# Altium schematic sheet uczelnia_robot.SchDoc
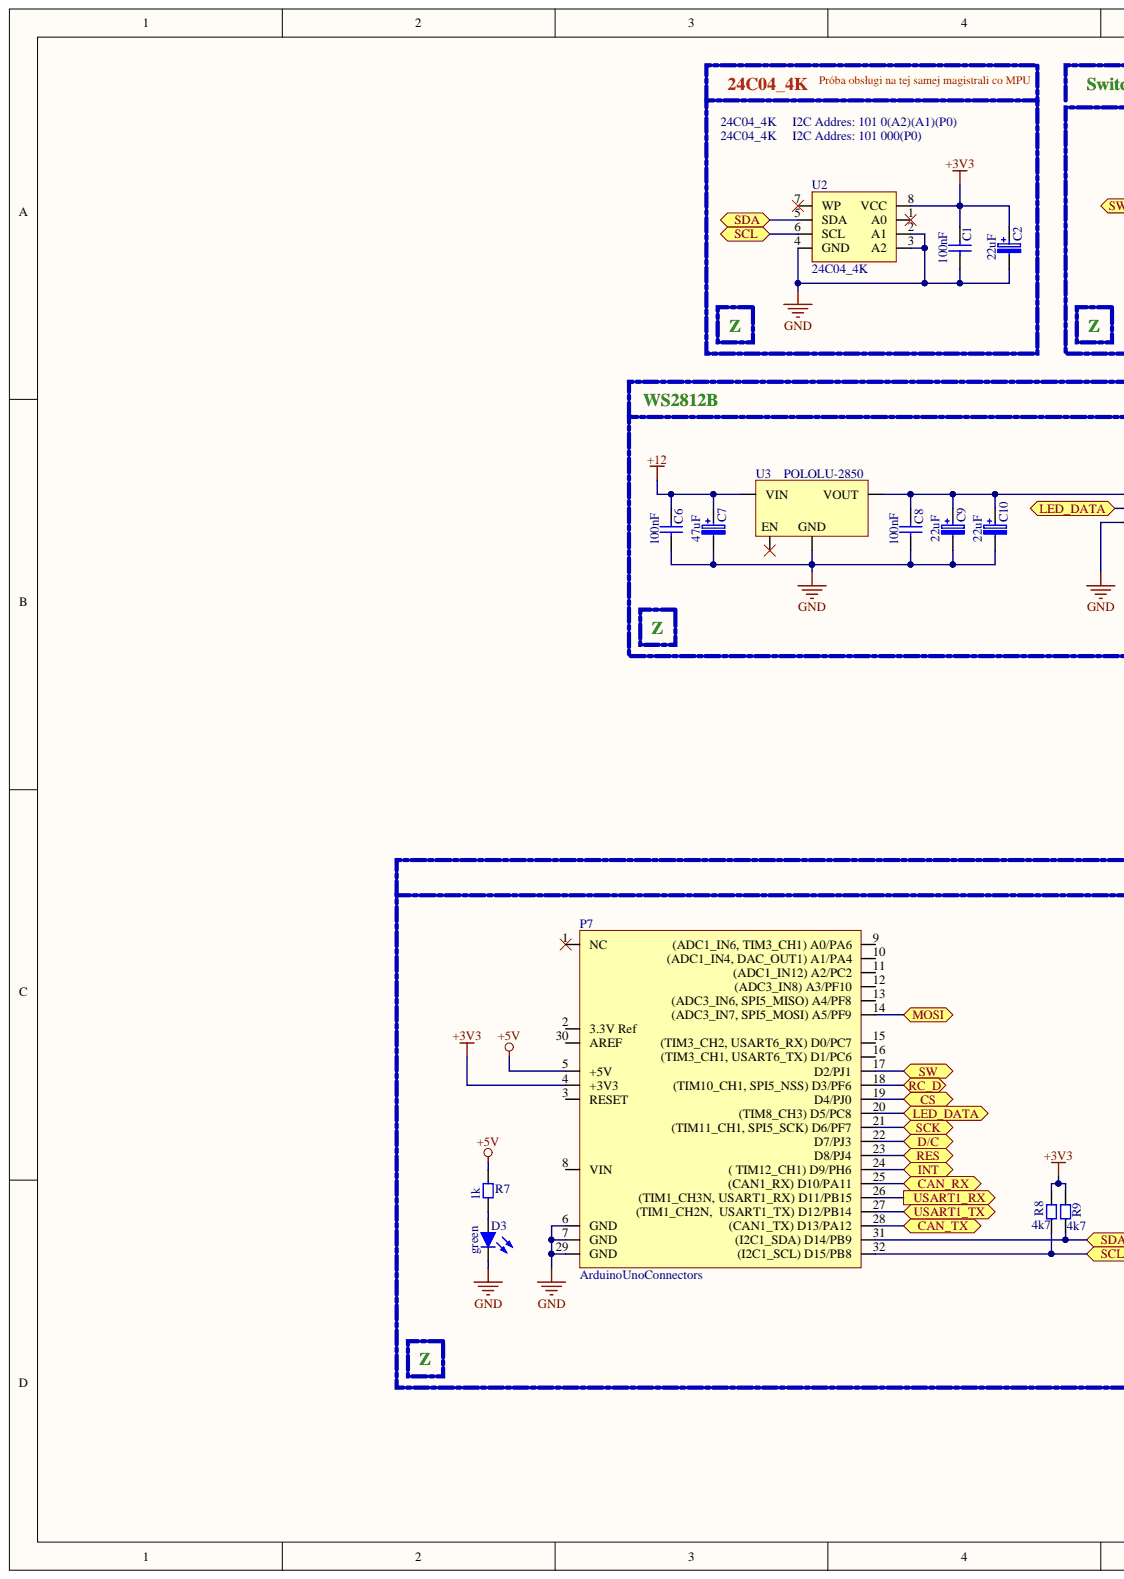
[diagram p0: uczelnia_robot.SchDoc, left]
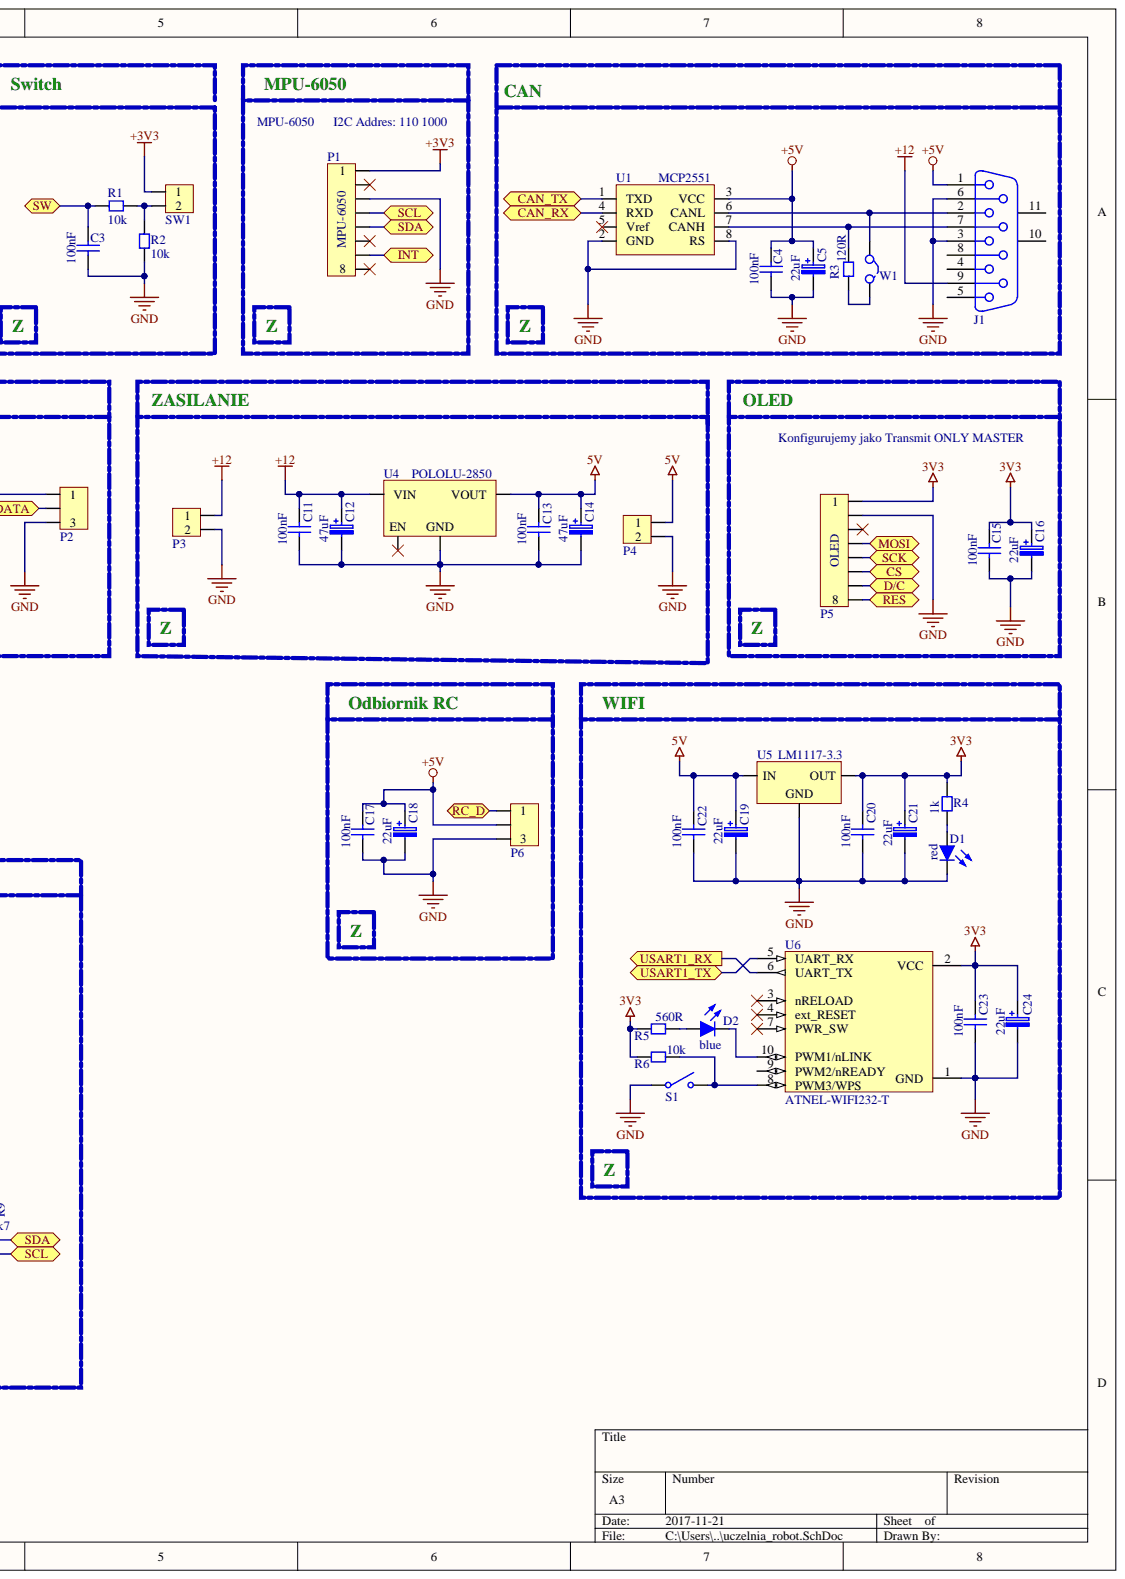
[diagram p1: uczelnia_robot.SchDoc, right]

## Parts
C1  100nF  [C0805]
C2  22uF  [C_SMD_6.3x5.8]
C3  100nF  [C0805]
C4  100nF  [C0805]
C5  22uF  [C_SMD_6.3x5.8]
C6  100nF  [C0805]
C7  47uF  [C_SMD_6.3x5.8]
C8  100nF  [C0805]
C9  22uF  [C_SMD_6.3x5.8]
C10  22uF  [C_SMD_6.3x5.8]
C11  100nF  [C0805]
C12  47uF  [C_SMD_6.3x5.8]
C13  100nF  [C0805]
C14  47uF  [C_SMD_6.3x5.8]
C15  100nF  [C0805]
C16  22uF  [C_SMD_6.3x5.8]
C17  100nF  [C0805]
C18  22uF  [C_SMD_6.3x5.8]
C19  22uF  [C_SMD_6.3x5.8]
C20  100nF  [C0805]
C21  22uF  [Tantal_A]
C22  100nF  [C0805]
C23  100nF  [C0805]
C24  22uF  [Tantal_A]
D1  red  [LED_G_1206]
D2  blue  [LED_G_1206]
D3  green  [LED_G_1206]
J1  D connector 9  [DSUB.385-2H9]  (value not drawn)
P1  MPU-6050  [8x1, 1.25]
P2  WS2812B  [WS2812B_DG308_2.54_3pin]  (value not drawn)
P3  12V_Header  [12V_15EDGRC-3.5/2P/90]  (value not drawn)
P4  5V_Header  [5V_15EDGRC-3.5/2P/90]  (value not drawn)
P5  OLED  [OLED_Header V,8x1,Pitch:2.54,female]
P6  RC  [RC_Header V,3x1,Pitch:2.54,female]
P7  ArduinoUnoConnectors  [arduino_uno_v3_shield]
R1  10k  [R0805]
R2  10k  [R0805]
R3  120R  [R0805]
R4  1k  [R0805]
R5  560R  [R0805]
R6  10k  [R0805]
R7  1k  [R0805]
R8  4k7  [R0805]
R9  4k7  [R0805]
S1  Przycisk  [Switch-SMD-KMR211G LFS]  (value not drawn)
SW1  Switch  [SWITCH_Header H ,2x1,pitch:2.54,male]  (value not drawn)
U1  MCP2551  [SO8_N]
U2  24C04_4K  [SO8_N]
U3  POLOLU-2850  [POLOLU-2850, Step-Down(D24V25F5)]
U4  POLOLU-2850  [POLOLU-2850, Step-Down(D24V25F5)]
U5  LM1117-3.3  [SOT223]
U6  ATNEL-WIFI232-T  [ATNEL-WIFI232-T]
W1  Jumper_NO  [Jumper (Header 2x1)]  (value not drawn)

## Ports
CAN_RX, CAN_TX, CS, D/C, INT, LED_DATA, MOSI, RC_D, RES, SCK, SCL, SDA, SW, USART1_RX, USART1_TX

## Nets
3V3: C15.1 C16.1 C20.1 C21.1 C23.1 C24.1 P5.1 R4.2 R5.2 R6.2 U5.2 U6.2(VCC)
5V: C13.1 C14.1 C19.1 C22.1 P4.1 U4.3(VOUT) U5.3
+3V3: C1.1 C2.1 P1.1 P7.4(+3V3) R8.2 R9.2 SW1.1 U2.8(VCC)
+5V: C4.1 C5.1 C17.1 C18.1 J1.1 P6.2 P7.5(+5V) R7.2 U1.3(VCC)
+12: C6.1 C7.1 C11.1 C12.1 J1.9 P3.1 U3.1(VIN) U4.1(VIN)
GND: C1.2 C2.2 C3.2 C4.2 C5.2 C6.2 C7.2 C8.2 C9.2 C10.2 C11.2 C12.2 C13.2 C14.2 C15.2 C16.2 C17.2 C18.2 C19.2 C20.2 C21.2 C22.2 C23.2 C24.2 D1.2 D3.2 J1.3 J1.6 P1.3 P2.3 P3.2 P4.2 P5.2 P6.3 P7.6(GND) P7.7(GND) P7.29(GND) R2.2 S1.1 U1.2(GND) U1.8(RS) U2.2(A1) U2.3(A2) U2.4(GND) U3.2 U4.2 U5.1 U6.1(GND)
CAN_RX: P7.25((CAN1_RX)_D10/PA11) U1.4(RXD) ; port CAN_RX
CAN_TX: P7.28((CAN1_TX)_D13/PA12) U1.1(TXD) ; port CAN_TX
CS: P5.6 P7.19(D4/PJ0) ; port CS
D/C: P5.7 P7.22(D7/PJ3) ; port D/C
INT: P1.7 P7.24((_TIM12_CH1)_D9/PH6) ; port INT
LED_DATA: P2.2 P7.20((TIM8_CH3)_D5/PC8) ; port LED_DATA
MOSI: P5.4 P7.14((ADC3_IN7,_SPI5_MOSI)_A5/PF9) ; port MOSI
RC_D: P6.1 P7.18 ; port RC_D
RES: P5.8 P7.23(D8/PJ4) ; port RES
SCK: P5.5 P7.21((TIM11_CH1,_SPI5_SCK)_D6/PF7) ; port SCK
SCL: P1.4 P7.32((I2C1_SCL)_D15/PB8) R8.1 U2.6(SCL) ; port SCL
SDA: P1.5 P7.31((I2C1_SDA)_D14/PB9) R9.1 U2.5(SDA) ; port SDA
SW: C3.1 P7.17(D2/PJ1) R1.1 ; port SW
USART1_RX: P7.26((TIM1_CH3N,_USART1_RX)_D11/PB15) U6.6(UART_TX) ; port USART1_RX
USART1_TX: P7.27(USART1_TX)_D12/PB14) U6.5(UART_RX) ; port USART1_TX
NetC8_1: C8.1 C9.1 C10.1 P2.1 U3.3(VOUT)
NetD1_1: D1.1 R4.1
NetD2_1: D2.1 R5.1
NetD2_2: D2.2 U6.10(PWM1/nLINK)
NetD3_1: D3.1 R7.1
NetJ1_2: J1.2 U1.6(CANL) W1.1
NetJ1_7: J1.7 R3.2 U1.7(CANH)
NetR1_2: R1.2 R2.1 SW1.2
NetR3_1: R3.1 W1.2
NetR6_1: R6.1 S1.2 U6.8(PWM3/WPS)

Not connected: J1 4 5 8 10 11; P1 2 6 8; P5 3; P7 1(NC) 2(3.3V_Ref) 3(RESET) 8(VIN) 9 10((ADC1_IN4,_DAC_OUT1)_A1/PA4) 11((ADC1_IN12)_A2/PC2) 12((ADC3_IN8)_A3/PF10) 13((ADC3_IN6,_SPI5_MISO)_A4/PF8) 15 16((TIM3_CH1,_USART6_TX)_D1/PC6) 30(AREF); U1 5(Vref); U2 1(A0) 7(WP); U3 4(EN); U4 4(EN); U6 3(nRELOAD) 4(ext_RESET) 7(PWR_SW) 9(PWM2/nREADY)

## Library data of the parts
C1 C3 C4 C6 C8 C11 C13 C15 C17 C20 C22 C23 = Kondensator zwykły: ceramiczny; Component Kind: Standard; LatestRevisionDate: 29-May-2009; LatestRevisionNote: IPC-7351 Footprint Added.; Library Name: my_lib_basic.IntLib; PackageDescription: Chip Capacitor; PackageReference: C1206; Published: 8-Jun-2000; Value: 100nF; Publisher: Altium Limited
C2 C5 C7 C9 C10 C12 C14 C16 C18 C19 = Kondensator elektr.: elektrolityczny; Component Kind: Standard; Library Name: my_lib_basic.IntLib
  C2 C5 C9 C10 C16 C18 C19: Value: 22uF
  C7 C12 C14: Value: 47uF
C21 C24 = Kondensator tantalowy: Tantalowy; Component Kind: Standard; Library Name: my_lib_basic.IntLib; Value: 22uF
D1-D3 = LED: Dioda świecąca; Component Kind: Standard; LatestRevisionDate: 08-Jul-2005; LatestRevisionNote: Mech Layer 1 removed.; Library Name: my_lib_basic.IntLib; PackageDescription: LED; 2 Leads; PackageReference: LED-0; Published: 8-Jun-2000; Publisher: Altium Limited
P1 = Header 8: Header, 8-pin; Component Kind: Standard; Library Name: my_lib_connectors.IntLib
P2 = WS2812B: Header, 3-pin; Component Kind: Standard; Library Name: SCH_Lib.SchLib
P3 = 12V_Header: 12V_Header, 2-pin; Component Kind: Standard; Library Name: SCH_Lib.SchLib
P4 = 5V_Header: 12V_Header, 2-pin; Component Kind: Standard; Library Name: SCH_Lib.SchLib
P5 = OLED: Header, 8-pin; Component Kind: Standard; Library Name: SCH_Lib.SchLib
P6 = RC: Header, 3-pin; Component Kind: Standard; Library Name: SCH_Lib.SchLib
P7 = ArduinoUnoConnectors: Arduino Uno V3 Connectors; Component Kind: Standard; Library Name: my_lib_connectors.IntLib
R1-R9 = Rezystor: Component Kind: Standard; Library Name: my_lib_basic.IntLib
  R1 R2 R6: Value: 10k
  R3: Value: 120R
  R4 R7: Value: 1k
  R5: Value: 560R
  R8 R9: Value: 4k7
S1 = Przycisk: Mikro-switch, chwilowy; Component Kind: Standard; LatestRevisionDate: 17-Jul-2002; LatestRevisionNote: Re-released for DXP Platform.; Library Name: my_lib_basic.IntLib; PackageDescription: Switch; 2 Leads; PackageReference: SPST-2; Published: 24-Mar-1999; Publisher: Altium Limited
SW1 = Switch: Switch, Header, 2-pin; Component Kind: Standard; Library Name: SCH_Lib.SchLib
U1 = MCP2551: CAN Transciver; Component Kind: Standard; Library Name: my_lib_microchips.IntLib
U2 = 24C04: Component Kind: Standard; Description: 24C04; Library Name: my_lib_microchips.IntLib
U3 U4 = POLOLU-2850(D24V25F5), Step Down, 5V, 2.5A, D24V25F5: Step Down Voltage Regulator, Pololu-2850, 5V, 2.5A, D24V25F5; Component Kind: Standard; Library Name: my_lib_modules.IntLib
W1 = Jumper_NO: Jumper_Normal_Open; Component Kind: Standard; Library Name: my_lib_basic.IntLib
J1 = D connector 9: Component Kind: Standard; Library Name: my_lib_connectors.IntLib
U5 = LM1117-3.3: Component Kind: Standard; Description: LM1117-3.3; Library Name: my_lib_microchips.IntLib
U6 = ATNEL-WIFI232-T: Component Kind: Standard; Description: ATNEL-WIFI232-T; Library Name: my_lib_modules.IntLib
